# Altium schematic sheet Control_Schem.SchDoc(Control_Schem)
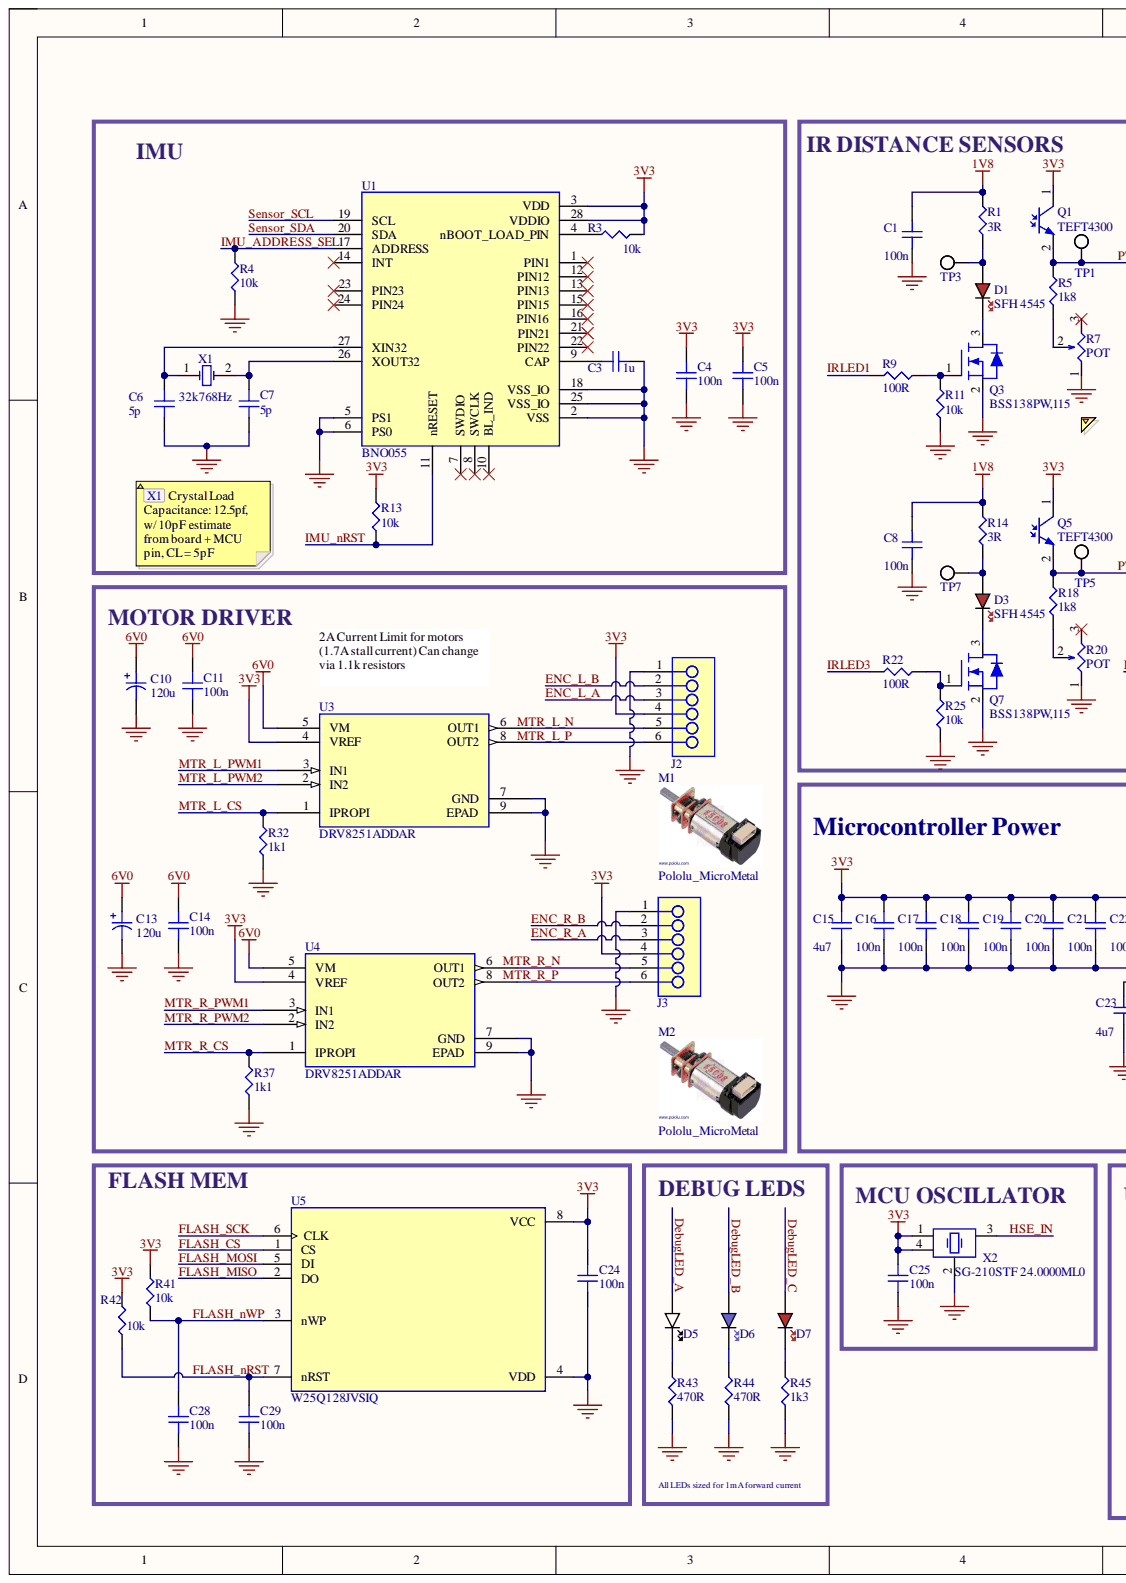
[diagram p0: Control_Schem.SchDoc, left]
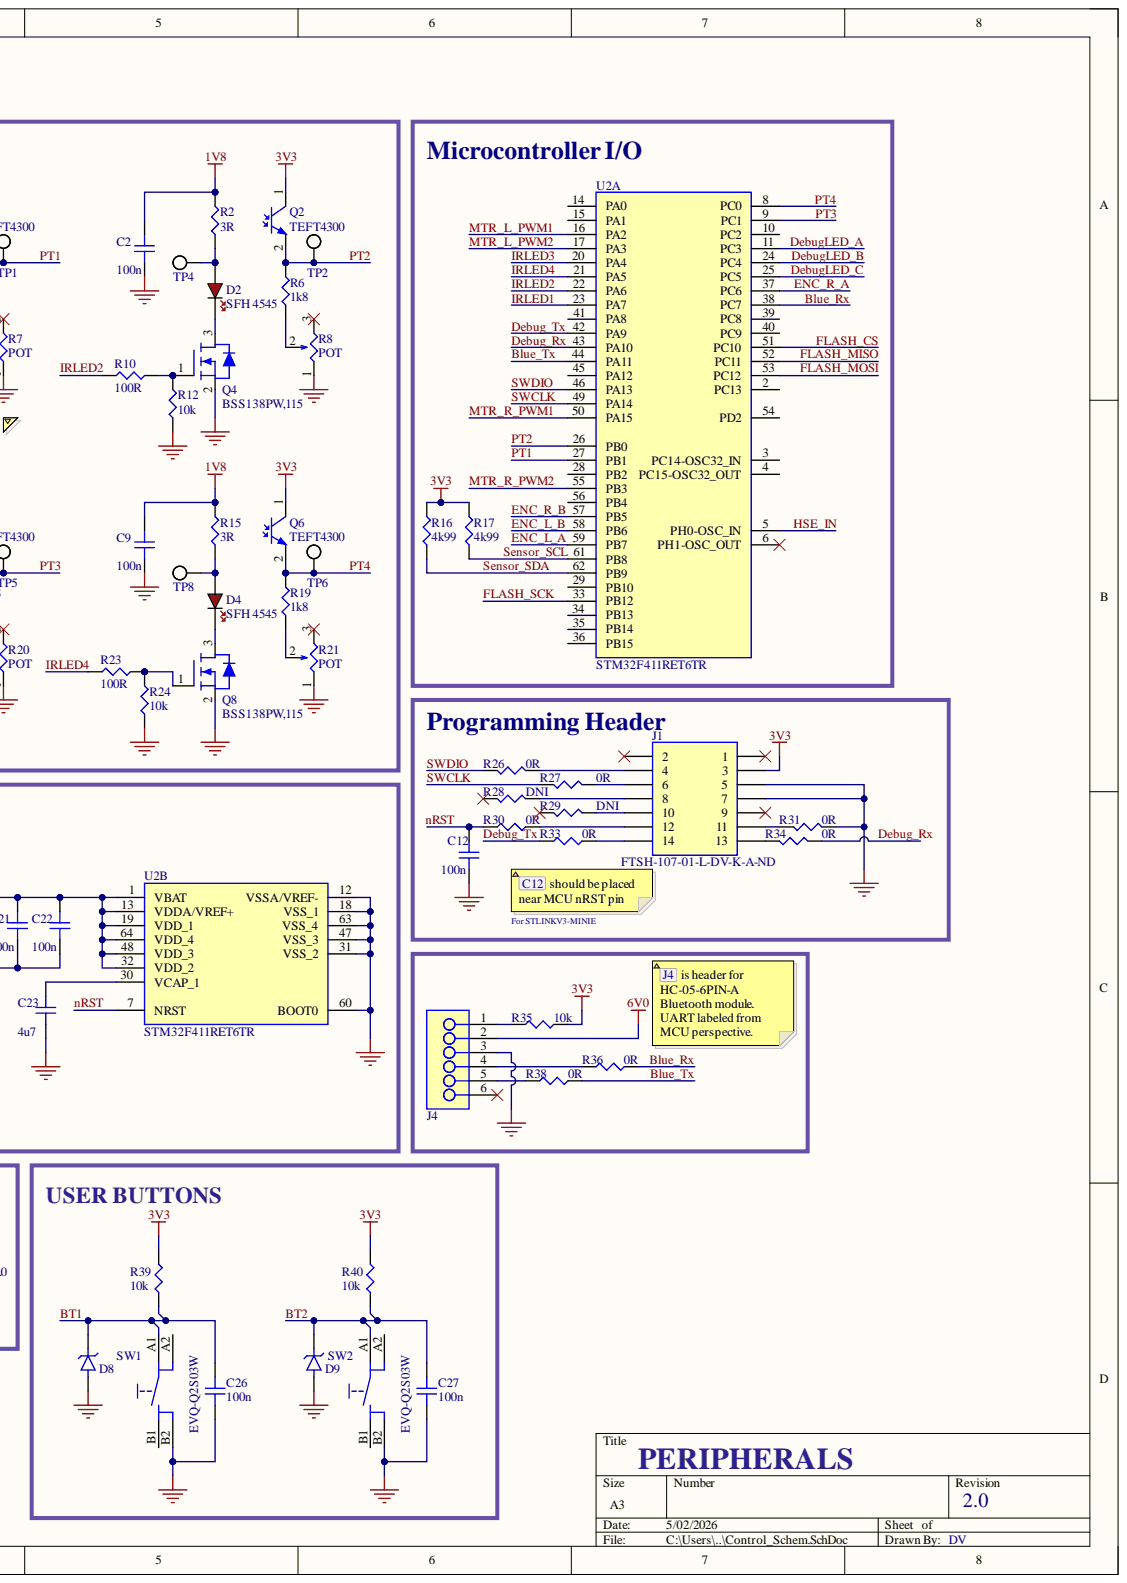
[diagram p1: Control_Schem.SchDoc, right]

## Parts
C1  100n  [CAP_0603]
C2  100n  [CAP_0603]
C3  1u  [CAP_0603]
C4  100n  [CAP_0603]
C5  100n  [CAP_0603]
C6  5p  [CAP_0603]
C7  5p  [CAP_0603]
C8  100n  [CAP_0603]
C9  100n  [CAP_0603]
C10  120u  [PCAP_SVP_C6_PAN-M]
C11  100n  [CAP_0603]
C12  100n  [CAP_0603]
C13  120u  [PCAP_SVP_C6_PAN-M]
C14  100n  [CAP_0603]
C15  4u7  [CAP_0603]
C16  100n  [CAP_0603]
C17  100n  [CAP_0603]
C18  100n  [CAP_0603]
C19  100n  [CAP_0603]
C20  100n  [CAP_0603]
C21  100n  [CAP_0603]
C22  100n  [CAP_0603]
C23  4u7  [CAP_0603]
C24  100n  [CAP_0603]
C25  100n  [CAP_0603]
C26  100n  [CAP_0603]
C27  100n  [CAP_0603]
C28  100n  [CAP_0603]
C29  100n  [CAP_0603]
D1  SFH 4545  [SFH4545]
D2  SFH 4545  [SFH4545]
D3  SFH 4545  [SFH4545]
D4  SFH 4545  [SFH4545]
D5  LED_White  [LED_0603]  (value not drawn)
D6  LED_Blue  [LED_0603]  (value not drawn)
D7  LED_Red  [LED_0603]  (value not drawn)
D8  65pF  [SOD-923]  (value not drawn)
D9  65pF  [SOD-923]  (value not drawn)
J1  Supplier Part Number 1: FTSH-107-01-L-DV-K-A-ND  [STM32_ProgrammingHeader]  (hidden comment: STDC14_DebugConnector)
J2  6POS  [JST_BM06B-SRSS-TB]  (value not drawn)
J3  6POS  [JST_BM06B-SRSS-TB]  (value not drawn)
J4  6POS  [P2.54_6POS]  (value not drawn)  (no BOM)
M1  Pololu_MicroMetal  [PololuMicroMetal]  (no BOM)
M2  Pololu_MicroMetal  [PololuMicroMetal]  (no BOM)
Q1  TEFT4300  [TEFT4300]
Q2  TEFT4300  [TEFT4300]
Q3  BSS138PW,115  [SOT23-3]
Q4  BSS138PW,115  [SOT23-3]
Q5  TEFT4300  [TEFT4300]
Q6  TEFT4300  [TEFT4300]
Q7  BSS138PW,115  [SOT23-3]
Q8  BSS138PW,115  [SOT23-3]
R1  3R  [RES_0603]
R2  3R  [RES_0603]
R3  10k  [RES_0603]
R4  10k  [RES_0603]
R5  1k8  [RES_0603]
R6  1k8  [RES_0603]
R7  POT  [TRIM_3296Y-1-203LF]
R8  POT  [TRIM_3296Y-1-203LF]
R9  100R  [RES_0603]
R10  100R  [RES_0603]
R11  10k  [RES_0603]
R12  10k  [RES_0603]
R13  10k  [RES_0603]
R14  3R  [RES_0603]
R15  3R  [RES_0603]
R16  4k99  [RES_0603]
R17  4k99  [RES_0603]
R18  1k8  [RES_0603]
R19  1k8  [RES_0603]
R20  POT  [TRIM_3296Y-1-203LF]
R21  POT  [TRIM_3296Y-1-203LF]
R22  100R  [RES_0603]
R23  100R  [RES_0603]
R24  10k  [RES_0603]
R25  10k  [RES_0603]
R26  0R  [RES_0603]
R27  0R  [RES_0603]
R28  DNI  [RES_0603]  (no BOM)
R29  DNI  [RES_0603]  (no BOM)
R30  0R  [RES_0603]
R31  0R  [RES_0603]
R32  1k1  [RES_0603]
R33  0R  [RES_0603]
R34  0R  [RES_0603]
R35  10k  [RES_0603]
R36  0R  [RES_0603]
R37  1k1  [RES_0603]
R38  0R  [RES_0603]
R39  10k  [RES_0603]
R40  10k  [RES_0603]
R41  10k  [RES_0603]
R42  10k  [RES_0603]
R43  470R  [RES_0603]
R44  470R  [RES_0603]
R45  1k3  [RES_0603]
SW1  EVQ-Q2S03W  [EVQQ2S03W]
SW2  EVQ-Q2S03W  [EVQQ2S03W]
TP1  TP  [TP-TH]  (no BOM)
TP2  TP  [TP-TH]  (no BOM)
TP3  TP  [TP-TH]  (no BOM)
TP4  TP  [TP-TH]  (no BOM)
TP5  TP  [TP-TH]  (no BOM)
TP6  TP  [TP-TH]  (no BOM)
TP7  TP  [TP-TH]  (no BOM)
TP8  TP  [TP-TH]  (no BOM)
U1  BNO055  [LGA28_5.2X3.8]
U2  STM32F411RET6TR  [LQFP-64_10X10]  (parts A,B)
U3  DRV8251ADDAR  [SOIC-8_EPAD]
U4  DRV8251ADDAR  [SOIC-8_EPAD]
U5  W25Q128JVSIQ  [SOIC-8]
X1  32k768Hz  [FP-ABS07-MFG]
X2  SG-210STF 24.0000ML0  [OSC_4-SMD(2.5x2)]

## Nets
1V8: C1.2 C2.2 C8.2 C9.2 R1.2 R2.2 R14.2 R15.2
3V3: C4.1 C5.1 C15.2 C16.2 C17.2 C18.2 C19.2 C20.2 C21.2 C22.2 C24.2 C25.2 J1.3 J2.4 J3.4 Q1.1 Q2.1 Q5.1 Q6.1 R3.1 R13.1 R16.1 R17.1 R35.2 R39.1 R40.1 R41.1 R42.1 U1.3(VDD) U1.28(VDDIO) U2.1(VBAT) U2.13(VDDA/VREF+) U2.19(VDD_1) U2.32(VDD_2) U2.48(VDD_3) U2.64(VDD_4) U3.4(VREF) U4.4(VREF) U5.8(VCC) X2.1 X2.4
6V0: C10.1 C11.1 C13.1 C14.1 J4.2 U3.5(VM) U4.5(VM)
GND: C1.1 C2.1 C3.1 C4.2 C5.2 C6.1 C7.1 C8.1 C9.1 C10.2 C11.2 C12.1 C13.2 C14.2 C15.1 C16.1 C17.1 C18.1 C19.1 C20.1 C21.1 C22.1 C23.1 C24.1 C25.1 C26.1 C27.1 C28.1 C29.1 D8.2 D9.2 J1.5 J1.7 J2.1 J3.1 J4.3 Q3.2 Q4.2 Q7.2 Q8.2 R4.2 R7.1 R8.1 R11.2 R12.2 R20.1 R21.1 R24.2 R25.2 R31.2 R32.2 R37.2 R43.2 R44.2 R45.2 SW1.B2 SW2.B2 U1.2(VSS) U1.5(PS1) U1.6(PS0) U1.18(VSS_IO) U1.25(VSS_IO) U2.12(VSSA/VREF-) U2.18(VSS_1) U2.31(VSS_2) U2.47(VSS_3) U2.60(BOOT0) U2.63(VSS_4) U3.7(GND) U3.9(EPAD) U4.7(GND) U4.9(EPAD) U5.4(VDD) X2.2
Blue_Rx: R36.2 U2.38(PC7)
Blue_Tx: R38.2 U2.44(PA11)
BT1: C26.2 D8.1 R39.2 SW1.A1
BT2: C27.2 D9.1 R40.2 SW2.A1
Debug_Rx: R34.2 U2.43(PA10)
Debug_Tx: R33.1 U2.42(PA9)
DebugLED_A: D5.2 U2.11(PC3)
DebugLED_B: D6.2 U2.24(PC4)
DebugLED_C: D7.2 U2.25(PC5)
ENC_L_A: J2.3 U2.59(PB7)
ENC_L_B: J2.2 U2.58(PB6)
ENC_R_A: J3.3 U2.37(PC6)
ENC_R_B: J3.2 U2.57(PB5)
FLASH_CS: U2.51(PC10) U5.1(CS)
FLASH_MISO: U2.52(PC11) U5.2(DO)
FLASH_MOSI: U2.53(PC12) U5.5(DI)
FLASH_nRST: C29.2 R42.2 U5.7(nRST)
FLASH_nWP: C28.2 R41.2 U5.3(nWP)
FLASH_SCK: U2.33(PB12) U5.6(CLK)
HSE_IN: U2.5(PH0-OSC_IN) X2.3
IMU_ADDRESS_SEL: R4.1 U1.17(ADDRESS)
IMU_nRST: R13.2 U1.11(nRESET)
IRLED1: R9.2 U2.23(PA7)
IRLED2: R10.2 U2.22(PA6)
IRLED3: R22.2 U2.20(PA4)
IRLED4: R23.2 U2.21(PA5)
MTR_L_CS: R32.1 U3.1(IPROPI)
MTR_L_PWM1: U2.16(PA2) U3.3(IN1)
MTR_L_PWM2: U2.17(PA3) U3.2(IN2)
MTR_R_CS: R37.1 U4.1(IPROPI)
MTR_R_PWM1: U2.50(PA15) U4.3(IN1)
MTR_R_PWM2: U2.55(PB3) U4.2(IN2)
nRST: C12.2 R30.1 U2.7(NRST)
PT1: Q1.2 R5.1 TP1.1 U2.27(PB1)
PT2: Q2.2 R6.1 TP2.1 U2.26(PB0)
PT3: Q5.2 R18.1 TP5.1 U2.9(PC1)
PT4: Q6.2 R19.1 TP6.1 U2.8(PC0)
Sensor_SCL: R17.2 U1.19(SCL) U2.61(PB8)
Sensor_SDA: R16.2 U1.20(SDA) U2.62(PB9)
SWCLK: R27.2 U2.49(PA14)
SWDIO: R26.2 U2.46(PA13)
NetC3_2: C3.2 U1.9(CAP)
NetC6_2: C6.2 U1.27(XIN32) X1.1
NetC7_2: C7.2 U1.26(XOUT32) X1.2
NetC23_2: C23.2 U2.30(VCAP_1)
NetD1_1: D1.1 Q3.3
NetD1_2: D1.2 R1.1 TP3.1
NetD2_1: D2.1 Q4.3
NetD2_2: D2.2 R2.1 TP4.1
NetD3_1: D3.1 Q7.3
NetD3_2: D3.2 R14.1 TP7.1
NetD4_1: D4.1 Q8.3
NetD4_2: D4.2 R15.1 TP8.1
NetD5_1: D5.1 R43.1
NetD6_1: D6.1 R44.1
NetD7_1: D7.1 R45.1
NetJ1_4: J1.4 R26.1
NetJ1_6: J1.6 R27.1
NetJ1_8: J1.8 R28.1
NetJ1_10: J1.10 R29.1
NetJ1_11: J1.11 R31.1
NetJ1_12: J1.12 R30.2
NetJ1_13: J1.13 R34.1
NetJ1_14: J1.14 R33.2
NetJ2_5: J2.5 U3.6(OUT1)
NetJ2_6: J2.6 U3.8(OUT2)
NetJ3_5: J3.5 U4.6(OUT1)
NetJ3_6: J3.6 U4.8(OUT2)
NetJ4_1: J4.1 R35.1
NetJ4_4: J4.4 R36.1
NetJ4_5: J4.5 R38.1
NetQ3_1: Q3.1 R9.1 R11.1
NetQ4_1: Q4.1 R10.1 R12.1
NetQ7_1: Q7.1 R22.1 R25.1
NetQ8_1: Q8.1 R23.1 R24.1
NetR3_2: R3.2 U1.4(nBOOT_LOAD_PIN)
NetR5_2: R5.2 R7.2
NetR6_2: R6.2 R8.2
NetR18_2: R18.2 R20.2
NetR19_2: R19.2 R21.2
MTR_L_N:
MTR_L_P:
MTR_R_N:
MTR_R_P:

Not connected: J1 1 2 9; J4 6; R7 3; R8 3; R20 3; R21 3; R28 2; R29 2; SW1 A2 B1; SW2 A2 B1; U1 1(PIN1) 7(SWDIO) 8(SWCLK) 10(BL_IND) 12(PIN12) 13(PIN13) 14(INT) 15(PIN15) 16(PIN16) 21(PIN21) 22(PIN22) 23(PIN23) 24(PIN24); U2 2(PC13) 3(PC14-OSC32_IN) 4(PC15-OSC32_OUT) 6(PH1-OSC_OUT) 10(PC2) 14(PA0) 15(PA1) 28(PB2) 29(PB10) 34(PB13) 35(PB14) 36(PB15) 39(PC8) 40(PC9) 41(PA8) 45(PA12) 54(PD2) 56(PB4)
